# Altium schematic sheet Sensors.SchDoc
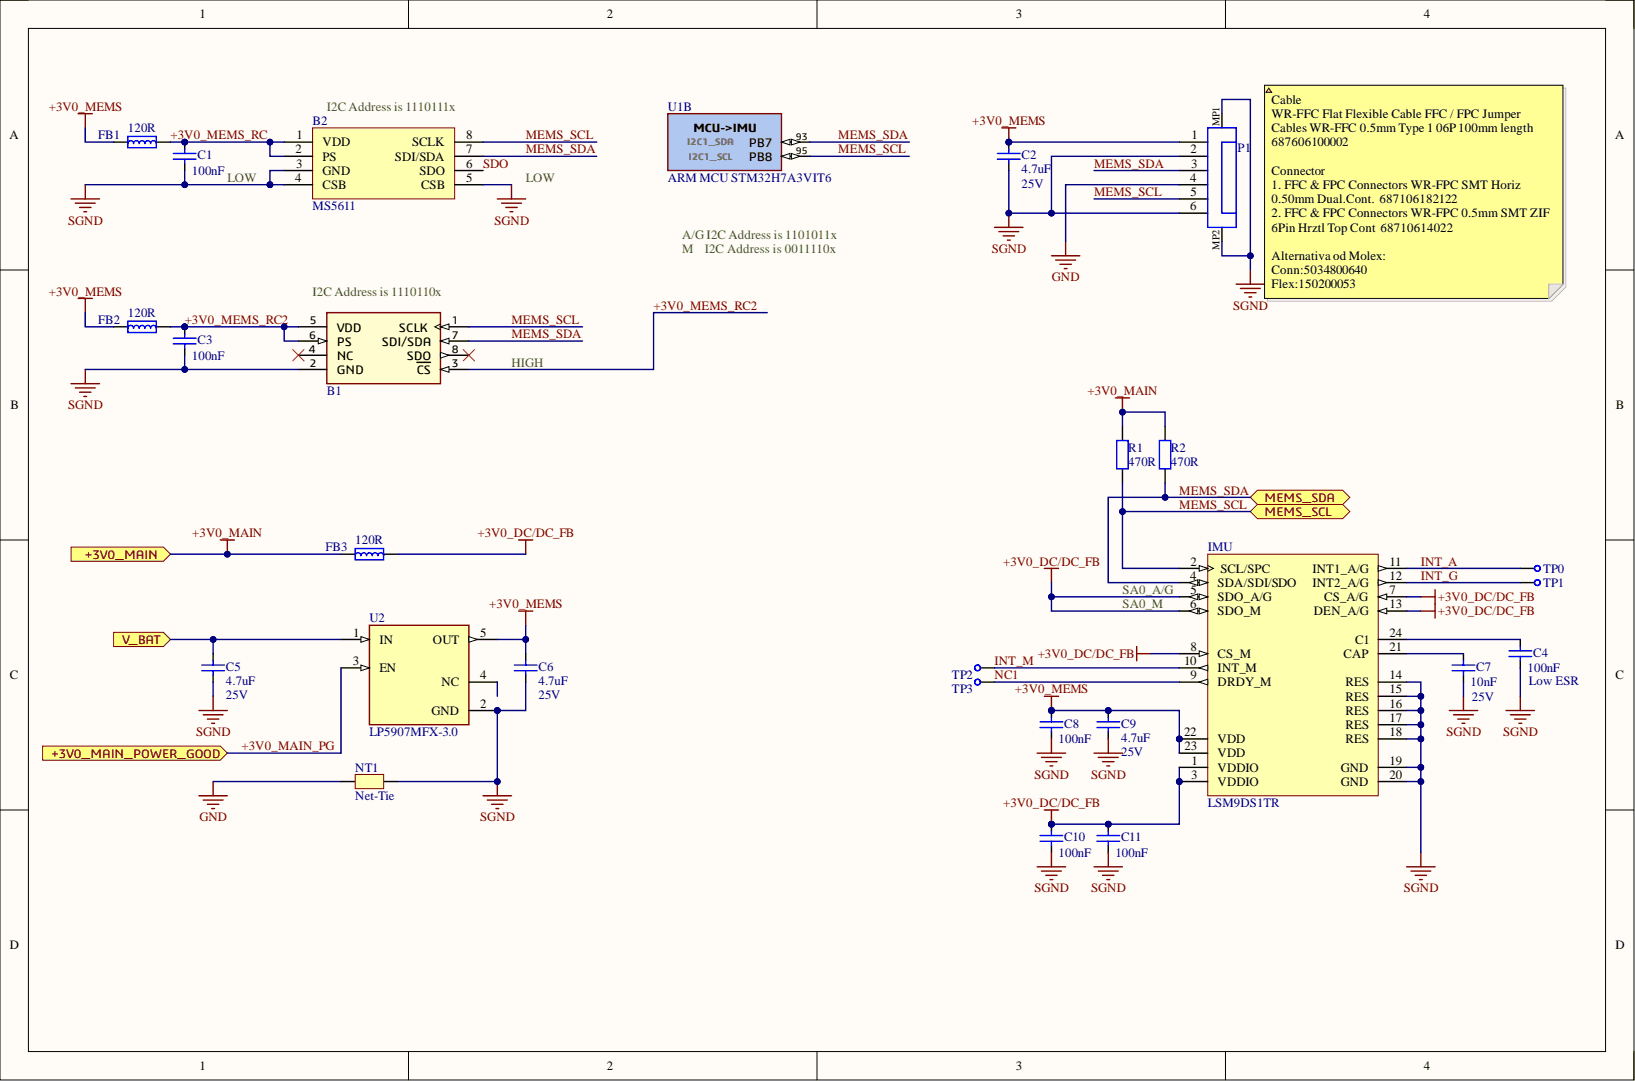
[diagram p0: Sensors.SchDoc]

## Parts
B1  MS580301BA01-00  [MS580314]  (value not drawn)
B2  MS5611  [MS5611]  (no BOM)
C1  100nF  [CAPACITOR_0402_(1005)]
C2  4.7uF  Voltage: 25V  [CAPACITOR_0603_(1608)]
C3  100nF  [CAPACITOR_0402_(1005)]
C4  100nF  ESR: Low ESR  [CAPACITOR_0402_(1005)]
C5  4.7uF  Voltage: 25V  [CAPACITOR_0603_(1608)]
C6  4.7uF  Voltage: 25V  [CAPACITOR_0603_(1608)]
C7  10nF  Voltage Rating: 25V  [CAPACITOR_0402_(1005)]
C8  100nF  [CAPACITOR_0402_(1005)]
C9  4.7uF  Voltage: 25V  [CAPACITOR_0603_(1608)]
C10  100nF  [CAPACITOR_0402_(1005)]
C11  100nF  [CAPACITOR_0402_(1005)]
FB1  120R  [FERRITE BEAD_0402_(1005)]
FB2  120R  [FERRITE BEAD_0402_(1005)]
FB3  120R  [FERRITE BEAD_0402_(1005)]
IMU  LSM9DS1TR  [STM-LGA-24_3500X3000X1000]
NT1  Net-Tie  [Net connection 1mm]  (no BOM)
P1  687106182122  [Flex 6P WR 687106182122]  (no BOM)
R1  470R  [RESISTOR_0603_(1608)]
R2  470R  [RESISTOR_0603_(1608)]
TP0  test point  [TP]  (value not drawn)  (no BOM)
TP1  test point  [TP]  (value not drawn)  (no BOM)
TP2  test point  [TP]  (value not drawn)  (no BOM)
TP3  test point  [TP]  (value not drawn)  (no BOM)
U1  ARM MCU STM32H7A3VIT6  Manufacturer Part Number: STM32H7A3VIT6  [STM-LQFP100_N - Edited]  (part B)
U2  LP5907MFX-3.0  [SOT23-5]

## Ports
+3V0_MAIN, +3V0_MAIN_POWER_GOOD, MEMS_SCL, MEMS_SDA, V_BAT

## Nets
+3V0_DC/DC_FB: C10.1 C11.1 FB3.2 IMU.1(VDDIO) IMU.3(VDDIO) IMU.5(SDO_A/G) IMU.6(SDO_M) IMU.7(CS_A/G) IMU.8(CS_M) IMU.13(DEN_A/G)
+3V0_MEMS: C2.1 C6.1 C8.1 C9.1 FB1.1 FB2.1 IMU.22(VDD) IMU.23(VDD) P1.1 U2.5(OUT)
GND: NT1.1 P1.4
SGND: B1.2(GND) B2.3(GND) B2.4(CSB) B2.5(CSB) C1.2 C2.2 C3.2 C4.2 C5.2 C6.2 C7.2 C8.2 C9.2 C10.2 C11.2 IMU.14(RES) IMU.15(RES) IMU.16(RES) IMU.17(RES) IMU.18(RES) IMU.19(GND) IMU.20(GND) NT1.2 P1.2 P1.6 P1.MP1 P1.MP2 U2.2(GND)
+3V0_MAIN: FB3.1 R1.1 R2.1 ; port +3V0_MAIN
+3V0_MAIN_PG: U2.3(EN) ; port +3V0_MAIN_POWER_GOOD
+3V0_MEMS_RC: B2.1(VDD) B2.2(PS) C1.1 FB1.2
+3V0_MEMS_RC2: B1.3(CS) B1.5(VDD) B1.6(PS) C3.1 FB2.2
INT_A: IMU.11(INT1_A/G) TP0.1
INT_G: IMU.12(INT2_A/G) TP1.1
INT_M: IMU.10(INT_M) TP2.1
MEMS_SCL: B1.1(SCLK) B2.8(SCLK) IMU.2(SCL/SPC) P1.5 R1.2 U1.95(PB8) ; port MEMS_SCL
MEMS_SDA: B1.7(SDI/SDA) B2.7(SDI/SDA) IMU.4(SDA/SDI/SDO) P1.3 R2.2 U1.93(PB7) ; port MEMS_SDA
NC1: IMU.9(DRDY_M) TP3.1
SDO: B2.6(SDO)
V_BAT: C5.1 U2.1(IN) ; port V_BAT
NetC4_1: C4.1 IMU.24(C1)
NetC7_1: C7.1 IMU.21(CAP)

Not connected: B1 4(NC) 8(SDO); U2 4(NC)

## Library data of the parts
B1 = MS5803: MINIATURE ALTIMETER MODULE; Manufacturer: TE Connectivity; Operating Supply Voltage: 3.6V; Case/Package: Module; Category: Pressure Sensors; Component Kind: Standard; ComponentLink1Description: https://www.te.com/commerce/DocumentDelivery/DDEController?Action=srchrtrv&DocNm=MS5803-01BA&DocType=Data+Sheet&DocLang=English&src-supplier=Digi-Key; ComponentLink1URL: https://www.te.com/commerce/DocumentDelivery/DDEController?Action=srchrtrv&DocNm=MS5803-01BA&DocType=Data+Sheet&DocLang=English&src-supplier=Digi-Key; Lead Free: Lead Free; Library Name: BB1_SCH_Library.SchLib; Manufacturer Part Number: MS580301BA01-00; Max Operating Temperature: 125°C; Min Operating Temperature: -40°C; Pricing 1: 1=13,82000 (USD); RoHS: No; Stock 1: 2395; Supplier 1: Digi-Key; Supplier Part Number 1: 223-1623-5-ND
B2 = MS5611: Manufacturer: TE Connectivity; Manufacturer Part Number 1: MS561101BA03-50; Operating Supply Voltage: 3.6V; ComponentLink1Description: http://www.farnell.com/datasheets/1756128.pdf?src-supplier=Farnell; ComponentLink1URL: http://www.farnell.com/datasheets/1756128.pdf?src-supplier=Farnell; ComponentLink2Description: https://www.te.com/commerce/DocumentDelivery/DDEController?Action=srchrtrv&DocNm=MS5611-01BA03&DocType=Data+Sheet&DocLang=English&src-supplier=Digi-Key; ComponentLink2URL: https://www.te.com/commerce/DocumentDelivery/DDEController?Action=srchrtrv&DocNm=MS5611-01BA03&DocType=Data+Sheet&DocLang=English&src-supplier=Digi-Key; ComponentLink3Description: http://www.farnell.com/datasheets/1756128.pdf?src-supplier=Newark; ComponentLink3URL: http://www.farnell.com/datasheets/1756128.pdf?src-supplier=Newark; Description: MS5611; Lead Free: Lead Free; Library Name: BB1_SCH_Library.SchLib; Max Operating Temperature: 85°C; Min Operating Temperature: -40°C; Pricing 1: 1=11,87000, 5=9,79000, 10=7,70000, 50=7,08000, 100=6,45000 (EUR); RoHS: No; Stock 1: 7410; Supplier 1: Farnell; Supplier Part Number 1: 2362662
C1 C3 C8 C10 C11 = CAPACITOR: ESR: 0.28R; Voltage Rating: 10V; Capacitance: 100nF; Component Kind: Standard; Description: CAPACITOR; Library Name: RLCFB.SchLib
C2 C5 C6 C9 = CAPACITOR: Manufacturer: Murata; Manufacturer Part Number 1: GRM188R61E475KE11D; Dielectric: X5R; ESR: 0.28R; Material: Ceramic; Tolerance: 10%; Capacitance: 4.7uF; Case Code (Imperial): 0603; Case Code (Metric): 1608; Case/Package: 0603; Component Kind: Standard; ComponentLink1Description: https://datasheet.ciiva.com/46444/1769893-46444982.pdf?src-supplier=Element14; ComponentLink1URL: https://datasheet.ciiva.com/46444/1769893-46444982.pdf?src-supplier=Element14; ComponentLink2Description: https://datasheet.ciiva.com/46444/1769893-46444983.pdf?src-supplier=Element14; ComponentLink2URL: https://datasheet.ciiva.com/46444/1769893-46444983.pdf?src-supplier=Element14; ComponentLink3Description: https://datasheet.ciiva.com/14891/partnumbering-e-01-14891102.pdf?src-supplier=Digi-Key; ComponentLink3URL: https://datasheet.ciiva.com/14891/partnumbering-e-01-14891102.pdf?src-supplier=Digi-Key; ComponentLink4Description: https://datasheet.ciiva.com/14891/partnumbering-e-01-14891103.pdf?src-supplier=Digi-Key; ComponentLink4URL: https://datasheet.ciiva.com/14891/partnumbering-e-01-14891103.pdf?src-supplier=Digi-Key; Depth: 0.8mm; Description: CAPACITOR; Height: 0.8mm; Lead Free: Lead Free; Length: 1.6mm; Max Operating Temperature: 85°C; Min Operating Temperature: -55°C; Mount: Surface Mount; Package Quantity: 4000; Packaging: Cut Tape; Pricing 1: 10=0,35700, 100=100,00000, 500=0,15900, 1000=0,12800, 2000=0,10200 (CHF); REACH SVHC: No SVHC; RoHS: Yes; Stock 1: 95119; Supplier 1: Farnell; Supplier Part Number 1: 2426959; Thickness: 0.031inch; Voltage Rating: 25V; Voltage Rating (DC): 25V; Width: 0.031inch; Library Name: RLCFB.SchLib
C4 = CAPACITOR: Voltage Rating: 10V; Capacitance: 100nF; Component Kind: Standard; Description: CAPACITOR; Library Name: RLCFB.SchLib
C7 = CAPACITOR: ESR: Low ESR; Capacitance: 10nF; Component Kind: Standard; Description: CAPACITOR; Library Name: RLCFB.SchLib
FB1-FB3 = FERRITE_BEAD: MURATA - BLM15PD121SN1D - Ferrite Bead, 0402 [1005 Metric], 120 ohm, 1.3 A, BLM15PD Series, 0.09 ohm, ± 25%; Current Rating: 1.3A; DC Resistance (DCR): 90mR; Material: Steel,Ferrite; Number of Circuits: 1; Test Frequency: 100MHz; Case Code (Imperial): 0402; Case Code (Metric): 1005; Case/Package: 0402; Category: Inductors; Component Kind: Standard; ComponentLink1Description: https://datasheet.ciiva.com/18093/getdatasheetpartid-195858-18093157.pdf?src-supplier=Verical; ComponentLink1URL: https://datasheet.ciiva.com/18093/getdatasheetpartid-195858-18093157.pdf?src-supplier=Verical; ComponentLink2Description: https://datasheet.ciiva.com/11800/0900766b811b5866-11800860.pdf?src-supplier=RSComponents; ComponentLink2URL: https://datasheet.ciiva.com/11800/0900766b811b5866-11800860.pdf?src-supplier=RSComponents; ComponentLink3Description: https://datasheet.ciiva.com/41425/001171686-da-01-en-chip-drossel0402-120r-1300ma-1-0x0-5mm-41425776.pdf?src-supplier=Conrad; ComponentLink3URL: https://datasheet.ciiva.com/41425/001171686-da-01-en-chip-drossel0402-120r-1300ma-1-0x0-5mm-41425776.pdf?src-supplier=Conrad; ComponentLink4Description: https://datasheet.ciiva.com/41449/001172205-da-01-en-drossel-0402-120r-11-0x0-5mm-10000st-41449495.pdf?src-supplier=Conrad; ComponentLink4URL: https://datasheet.ciiva.com/41449/001172205-da-01-en-drossel-0402-120r-11-0x0-5mm-10000st-41449495.pdf?src-supplier=Conrad; ComponentLink5Description: https://datasheet.ciiva.com/1012/l0110e-1012434.pdf?src-supplier=Digi-Key; ComponentLink5URL: https://datasheet.ciiva.com/1012/l0110e-1012434.pdf?src-supplier=Digi-Key; ComponentLink6Description: https://datasheet.ciiva.com/1012/l0110e-1012435.pdf?src-supplier=Digi-Key; ComponentLink6URL: https://datasheet.ciiva.com/1012/l0110e-1012435.pdf?src-supplier=Digi-Key; ComponentLink7Description: https://datasheet.ciiva.com/1012/l0110e-1012436.pdf?src-supplier=Digi-Key; ComponentLink7URL: https://datasheet.ciiva.com/1012/l0110e-1012436.pdf?src-supplier=Digi-Key; Core Material: Ferrite; DC Current: 1.3A; Depth: 0.55mm; Height: 0.5mm; Impedance@100MHz: 120R; Lead Free: Lead Free; Length: 1mm; Manufacturer: Murata; Manufacturer Part Number: BLM15PD121SN1D; Max Current Rating: 1.3A; Max DC Current: 1.3A; Max Operating Temperature: 125°C; Military Standard: Not; Min Operating Temperature: -55°C; Number of Lines: 1; Number of Pins: 2; Package Quantity: 1; Packaging: Tape and Reel; Pricing 1: 10=0,03290, 100=0,02380, 500=0,01790, 2500=0,01340, 5000=0,01100 (EUR); Radiation Hardening: No; REACH SVHC: No SVHC; RoHS: Yes; Stock 1: 137232; Supplier 1: Farnell; Supplier Part Number 1: 2443229; Termination: SMD/SMT; Tolerance: 25%; Width: 0.5mm; Library Name: RLCFB.SchLib
IMU = IMU_LSM9DS1: iNEMO Inertial Module: 3D Accelerometer, 3D Gyroscope, 3D Magnetometer, 1.9 to 3.6 V, -40 to 85 degC, 24-Pin LGA, RoHS, Tape and Reel; Manufacturer: STMicroelectronics; Acceleration Range: ±2g,±4g,±8g,±16g; Gyroscope Range: ±245°/s,±500°/s,±2000°/s; MEMS Module Function: Tri-AxisGyroscope,Tri-AxisAccelerometer,Tri-AxisMagnetometer; No. of Pins: 24Pins; Supply Voltage Max: 3.6V; Supply Voltage Min: 1.9V; SVHC: NoSVHC(17-Dec-2015); Component Kind: Standard; Datasheet URL: http://www2.st.com/content/ccc/resource/technical/document/datasheet/1e/3f/2a/d6/25/eb/48/46/DM00103319.pdf/files/DM00103319.pdf/jcr:content/translations/en.DM00103319.pdf; Datasheet Version: Rev. 3, 03/2015; Library Name: BB1_SCH_Library.SchLib; Manufacturer URL: http://www.st.com/; Mounting Technology: SurfaceMount; MSL: MSL3-168hours; Package Description: 24-Pin Plastic Land Grid Array Package, Body 3.65 x 3.15 mm, Pitch 0.43 mm; Package Reference: LGA-24_3500X3000X1000; Packaging: CutTape; PartNumber: LSM9DS1; Product Range: -; Sensor Case Style: LGA
P1 = Flex 6P Horizontal WR: SMT ZIF Connectors WR-FPC, Horizontal, Back Locking Dual Contact, pitch 0.5 mm, 6 pins; Manufacturer: Wurth Elektronik; Contact Resistance: 30mOhm; Pin Number: 6; Pitch: 0.5mm; Rated Current: 0.5A; Withstanding Voltage: 250VAC; Working Voltage: 50VAC; Contact Design: BackLockingDualContact; Datasheet URL: https://katalog.we-online.de/em/datasheet/687106182122.pdf; Library Name: BB1_SCH_Library.SchLib; Manufacturer URL: http://www.we-online.de; Mounting Technology: SMT; Operating Temperature: -55to85degC; Orientation: Horizontal; Package Reference: 687106182122; Packaging: TapeandReel; Part Number: 687106182122; Publisher: WurthElektronik
R1 R2 = RESISTOR: Power Rating: 500mW; Component Kind: Standard; Description: RESISTOR; Resistance: 470R; Library Name: RLCFB.SchLib
U1 = STM32H7A3VIT6_LQFP100: STM32H7A3VIT6 -  32-bit Arm® Cortex®-M7 280 MHz MCUs, up to 2-Mbyte Flash memory, 1.4Mbyte RAM, 46 com. and analog interfaces; Manufacturer: STMicroelectronics; Category: Microcontrollers; Component Kind: Standard; ComponentLink1Description: Datasheet; ComponentLink1URL: https://www.st.com/resource/en/datasheet/stm32h7a3vi.pdf; Library Name: BB1_SCH_Library.SchLib; RoHS: No; Supplier 1: Mouser; Supplier Part Number 1: 511-STM32H7A3VIT6
U2 = LDO_3V0_Low_Noise_250mA: IC REG LINEAR 3V 250MA SOT23-5; Manufacturer: Texas Instruments; Manufacturer Part Number: LP5907MFX-3.0/NOPB; Accuracy Percentage: -3%; Dropout Voltage: 250mV; Max Junction Temp: +125°C; Max Supply Voltage: 5.5V; Min Supply Voltage: 2.2V; Nominal Supply Current: 12-250uA; Number Of Outputs: 1; Output Type: Fixed; Standoff Height: 0mm; Ambient Temperature Range High: +85°C; Ambient Temperature Range Low: -40°C; Automotive: No; Category: IC; Component Kind: Standard; ComponentLink2Description: Datasheet; ComponentLink2URL: http://www.ti.com/lit/ds/symlink/lp5907.pdf; Device Class L1: Integrated Circuits (ICs); Device Class L2: Power Management ICs; Device Class L3: Voltage Regulators - Linear; Digikey Description: IC REG LINEAR 3V 250MA SOT23-5; Height: 1.45mm; Lead Free: Yes; Library Name: BB1_SCH_Library.SchLib; Mouser Description: LDO Voltage Regulators 250mA Ultra-Lo Noise LDO Reg; Octopart: https://octopart.com/lp5907mfx-3.0%2Fnopb-texas+instruments-25892529; Output Current: 250mA; Output Voltage: 3V; Package: DBV0005A; RoHS: TRUE; Temperature: -40°C to +125°C; Temperature Range High: +125°C; Temperature Range Low: -40°C
NT1 = Net-Tie: Description: Net-Tie; Library Name: BB1_SCH_Library.SchLib
TP0-TP3 = test point: Library Name: BB1_SCH_Library.SchLib
